# Altium schematic sheet AUX.SchDoc(AUX)
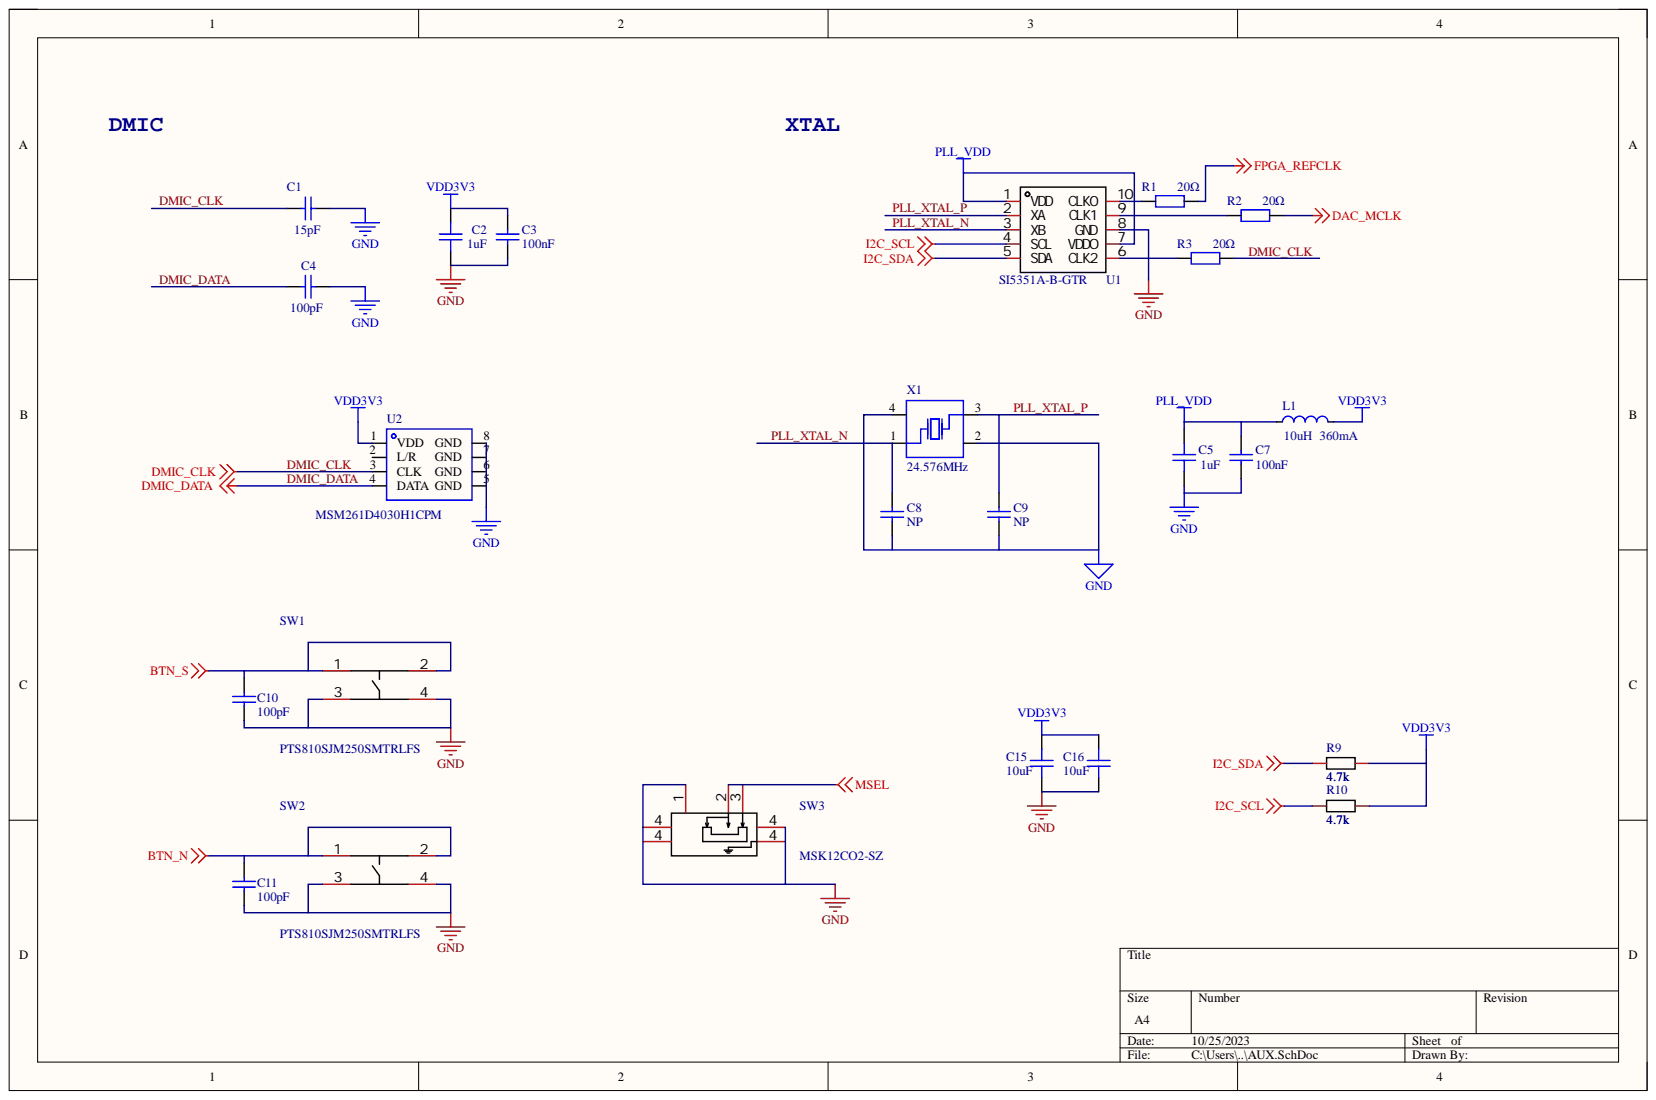
[diagram p0: AUX.SchDoc]

## Parts
C1  value 15pF  [C0402]
C2  value 1uF  [C0603]
C3  value 100nF  [C0402]
C4  value 100pF  [C0402]
C5  value 1uF  [C0603]
C7  value 100nF  [C0402]
C8  value NP  [C0402]
C9  value NP  [C0402]
C10  value 100pF  [C0402]
C11  value 100pF  [C0402]
C15  value 10uF  [C0603]
C16  value 10uF  [C0603]
L1  value 10uH  Rated Current: 360mA  [L0805]
R1  value 20Ω  [R0402]
R2  value 20Ω  [R0402]
R3  value 20Ω  [R0402]
R9  4.7k  [R0402]
R10  4.7k  [R0402]
SW1  PTS810SJM250SMTRLFS  [KEY-SMD_4P-L4.2-W3.2-P2.20-LS4.6]
SW2  PTS810SJM250SMTRLFS  [KEY-SMD_4P-L4.2-W3.2-P2.20-LS4.6]
SW3  MSK12CO2-SZ  [SW-TH_MSK12C02]
U1  SI5351A-B-GTR  [MSOP-10_L3.0-W3.0-P0.50-LS5.0-BL]
U2  (no value)  [MIC-SMD_8P-L3.0-W4.0-P0.85-BL]
X1  value 24.576MHz  [OSC-SMD_4P-L3.2-W2.5-BL]

## Nets
BTN_N: C11.2 SW2.1 SW2.2
BTN_S: C10.2 SW1.1 SW1.2
DAC_MCLK: R2.2
FPGA_REFCLK: R1.2
GND: C1.2 C2.1 C3.1 C4.2 C5.1 C7.1 C8.2 C9.2 C10.1 C11.1 C15.2 C16.2 SW1.3 SW1.4 SW2.3 SW2.4 SW3.1 SW3.4 U1.8(GND) U2.5(GND) U2.6(GND) U2.7(GND) U2.8(GND) X1.2 X1.4
I2C_SCL: R10.1 U1.4(SCL)
I2C_SDA: R9.1 U1.5(SDA)
MSEL: SW3.2 SW3.3
PLL_VDD: C5.2 C7.2 L1.1 U1.1(VDD) U1.7(VDDO)
VDD3V3: C2.2 C3.2 C15.1 C16.1 L1.2 R9.2 R10.2 U2.1(VDD)
DMIC_CLK: C1.1 R3.2 U2.3(CLK)
DMIC_DATA: C4.1 U2.4(DATA)
PLL_XTAL_N: C8.1 U1.3(XB) X1.1
PLL_XTAL_P: C9.1 U1.2(XA) X1.3
NetR1_1: R1.1 U1.10(CLK0)
NetR2_1: R2.1 U1.9(CLK1)
NetR3_1: R3.1 U1.6(CLK2)

Not connected: U2 2(L/R)

## Library data of the parts
C1 C8 C9 = 0402CG150J500NT: Manufacturer: FH(风华); Add into BOM: yes; Temperature Coefficient: C0G; Tolerance: ±5%; Voltage Rated: 50V; Component Kind: Standard; Convert to PCB: yes; Datasheet: https://atta.szlcsc.com/upload/public/pdf/source/20170923/C1548_1506149266133988355.pdf; Device: 0402CG150J500NT; JLCPCB Part Class: Basic Part; LCSC Part Name: 15pF ±5% 50V; Manufacturer Part: 0402CG150J500NT; PCB3D: C0402_L1.0-W0.5-H0.5; Supplier: LCSC; Supplier Footprint: 0402; Supplier Part: C1548; Symbol: 0402CG150J500NT
C2 C5 = CL05A105KA5NQNC: Manufacturer: SAMSUNG(三星); Add into BOM: yes; Temperature Coefficient: X5R; Tolerance: ±10%; Voltage Rated: 25V; Component Kind: Standard; Convert to PCB: yes; Datasheet: https://atta.szlcsc.com/upload/public/pdf/source/20160103/1457707735223.pdf; Device: CL05A105KA5NQNC; JLCPCB Part Class: Basic Part; LCSC Part Name: 1uF ±10% 25V; Manufacturer Part: CL05A105KA5NQNC; PCB3D: C0402_L1.0-W0.5-H0.5; Supplier: LCSC; Supplier Footprint: 0402; Supplier Part: C52923; Symbol: CL05A105KA5NQNC
C3 C7 = CL05B104KO5NNNC: Manufacturer: SAMSUNG(三星); Add into BOM: yes; Temperature Coefficient: X7R; Tolerance: ±10%; Voltage Rated: 16V; Component Kind: Standard; Convert to PCB: yes; Datasheet: https://atta.szlcsc.com/upload/public/pdf/source/20160103/1457707674891.pdf; Device: CL05B104KO5NNNC; JLCPCB Part Class: Basic Part; LCSC Part Name: 100nF ±10% 16V; Manufacturer Part: CL05B104KO5NNNC; PCB3D: C0402_L1.0-W0.5-H0.5; Supplier: LCSC; Supplier Footprint: 0402; Supplier Part: C1525; Symbol: CL05B104KO5NNNC
C4 C10 C11 = 0402CG101J500NT: Manufacturer: FH(风华); Add into BOM: yes; Temperature Coefficient: C0G; Tolerance: ±5%; Voltage Rated: 50V; Component Kind: Standard; Convert to PCB: yes; Datasheet: https://atta.szlcsc.com/upload/public/pdf/source/20170923/C1546_1506149265265988353.pdf; Device: 0402CG101J500NT; JLCPCB Part Class: Basic Part; LCSC Part Name: 100pF ±5% 50V; Manufacturer Part: 0402CG101J500NT; PCB3D: C0402_L1.0-W0.5-H0.5; Supplier: LCSC; Supplier Footprint: 0402; Supplier Part: C1546; Symbol: 0402CG101J500NT
C15 C16 = CL10A106KP8NNNC: Manufacturer: SAMSUNG(三星); Add into BOM: yes; Temperature Coefficient: X5R; Tolerance: ±10%; Voltage Rated: 10V; Component Kind: Standard; Convert to PCB: yes; Datasheet: https://atta.szlcsc.com/upload/public/pdf/source/20160103/1457707703378.pdf; Device: CL10A106KP8NNNC; JLCPCB Part Class: Basic Part; LCSC Part Name: 10uF ±10% 10V; Manufacturer Part: CL10A106KP8NNNC; PCB3D: C0603_L1.6-W0.8-H0.8; Supplier: LCSC; Supplier Footprint: 0603; Supplier Part: C19702; Symbol: CL10A106KP8NNNC
L1 = SLM2012100MIT: Manufacturer: Sunltech(韩国顺磁); Add into BOM: yes; DC Resistance (DCR): 550mΩ; Tolerance: ±20%; Component Kind: Standard; Convert to PCB: yes; Datasheet: https://atta.szlcsc.com/upload/public/pdf/source/20180528/C216156_746607D01E5EB4C50E0D2BE40685D2FE.pdf; Device: SLM2012100MIT; JLCPCB Part Class: Extended Part; LCSC Part Name: 10uH ±20% 360mA 550mΩ; Manufacturer Part: SLM2012100MIT; PCB3D: R0805_L2.0-W1.3-H0.6; Ratings: -; Saturation Current (Isat): -; Supplier: LCSC; Supplier Footprint: 0805; Supplier Part: C2831365; Symbol: SLM2012100MIT
R1-R3 = 0603WAF200JT5E: Manufacturer: UNI-ROYAL(厚声); Add into BOM: yes; Overload Voltage (Max): 75V; Power(Watts): 1/10W; Temperature Coefficient: ±100ppm/; Tolerance: ±1%; Component Kind: Standard; Convert to PCB: yes; Datasheet: https://atta.szlcsc.com/upload/public/pdf/source/20200306/C422600_1E6D84923E4A46A82E41ADD87F860B5C.pdf; Device: 0603WAF200JT5E; JLCPCB Part Class: Basic Part; LCSC Part Name: 20Ω ±1%; Manufacturer Part: 0603WAF200JT5E; Operating Temperature Range: -55~+155; PCB3D: R0603_L1.6-W0.8-H0.8; Supplier: LCSC; Supplier Footprint: 0603; Supplier Part: C22950; Symbol: 0603WAF200JT5E; Type: 厚膜电阻
R9 R10 = Res_0603: Add into BOM: yes; Symbol: 电阻; Component Kind: Standard; Convert to PCB: yes; Device: Res_0603; Name: 4.7k; PCB3D: R0603_L1.6-W0.8-H0.5; Supplier Footprint: 0603; Value: 4.7k
SW1 SW2 = PTS810SJM250SMTRLFS: Manufacturer: C&K; Actuator Style: 圆形按钮; Add into BOM: yes; Circuit: 单刀单掷; Contact Current: 50mA; Switch Height: 2.5mm; Switch Length: 4.2mm; Switch Width: 3.2mm; Voltage Rating (DC): 16V; Component Kind: Standard; Convert to PCB: yes; Datasheet: https://atta.szlcsc.com/upload/public/pdf/source/20180704/C116501_199B7AD0EF93D1F69D47FA53D559C299.pdf; Device: PTS810SJM250SMTRLFS; JLCPCB Part Class: Extended Part; LCSC Part Name: 4.2*3.2*2.5mm 立贴 轻触开关; Manufacturer Part: PTS810SJM250SMTRLFS; Mechanical Life: -; Mounting Style: 立贴; Name: PTS810SJM250SMTRLFS; PCB3D: KEY-SMD_4P-L4.2-W3.2-H2.5-LS4.6-P2.2; Supplier: LCSC; Supplier Footprint: SMD; Supplier Part: C116501; Symbol: PTS810SJM250SMTRLFS; With Lamp: -
SW3 = MSK12CO2-SZ: Manufacturer: SHOU HAN(首韩); Add into BOM: yes; Component Kind: Standard; Convert to PCB: yes; Datasheet: https://atta.szlcsc.com/upload/public/pdf/source/20190925/C431540_89155AEF0758B9AD1B2D805F83FB12E3.pdf; Device: MSK12CO2-SZ; JLCPCB Part Class: Extended Part; LCSC Part Name: 迷你贴片拨动/两档侧拨 7脚 白柄，柄长1.5mm,耐温，高品质带金属丝; Manufacturer Part: MSK12CO2-SZ; Name: MSK12CO2-SZ; PCB3D: SW-SMD_3P-L6.6-W2.7-LS7.8-P1.50; Supplier: LCSC; Supplier Footprint: SMD; Supplier Part: C2681568; Symbol: MSK12CO2-SZ
U1 = SI5351A-B-GTR: Manufacturer: SILICON LABS(芯科); Add into BOM: yes; Component Kind: Standard; Convert to PCB: yes; Datasheet: https://atta.szlcsc.com/upload/public/pdf/source/20201217/C504891_43D9F8D26F790B9DA481E85A362B0988.pdf; Device: SI5351A-B-GTR; JLCPCB Part Class: Extended Part; LCSC Part Name: SI5351A-B-GTR; Manufacturer Part: SI5351A-B-GTR; Name: SI5351A-B-GTR; PCB3D: MSOP-10_L3.0-W3.0-H1.1-LS5.0-P0.50; Supplier: LCSC; Supplier Footprint: MSOP-10(3x3x0.5); Supplier Part: C504891; Symbol: SI5351A-B-GTR
U2 = MSM261D4030H1CPM: Manufacturer: MEMS(敏芯微); Add into BOM: yes; Component Kind: Standard; Convert to PCB: yes; Datasheet: https://atta.szlcsc.com/upload/public/pdf/source/20201204/C966942_B60AB8B480FB10DBF6E1564FEF312E24.pdf; Device: MSM261D4030H1CPM; JLCPCB Part Class: Extended Part; LCSC Part Name: MSM261D4030H1CPM; Manufacturer Part: MSM261D4030H1CPM; PCB3D: MIC-SMD_8P-L3.0-W4.0-P0.85-BL; Supplier: LCSC; Supplier Footprint: SMD,4x3x1mm; Supplier Part: C966942; Symbol: MSM261D4030H1CPM
X1 = X322525MOB4SI: Manufacturer: YXC扬兴科技; Add into BOM: yes; Crystal Type: 贴片无源晶振; Frequency Stability: ±30ppm; Frequency Tolerance: ±10ppm; Load Capacitance: 12pF; Component Kind: Standard; Convert to PCB: yes; Datasheet: https://atta.szlcsc.com/upload/public/pdf/source/20210329/C112971_1F611BDC486E193C1706F6D8EB5AA189.pdf; Device: X322525MOB4SI; JLCPCB Part Class: Basic Part; LCSC Part Name: 25MHz ±10ppm 12pF; Manufacturer Part: X322525MOB4SI; Operating Temperature: -40~+85; PCB3D: OSC-SMD_4P-L3.2-W2.5-H1.0; Supplier: LCSC; Supplier Footprint: SMD3225-4P; Supplier Part: C9006; Symbol: X322525MOB4SI
